# Altium schematic sheet 辅助电源.SchDoc(辅助电源)
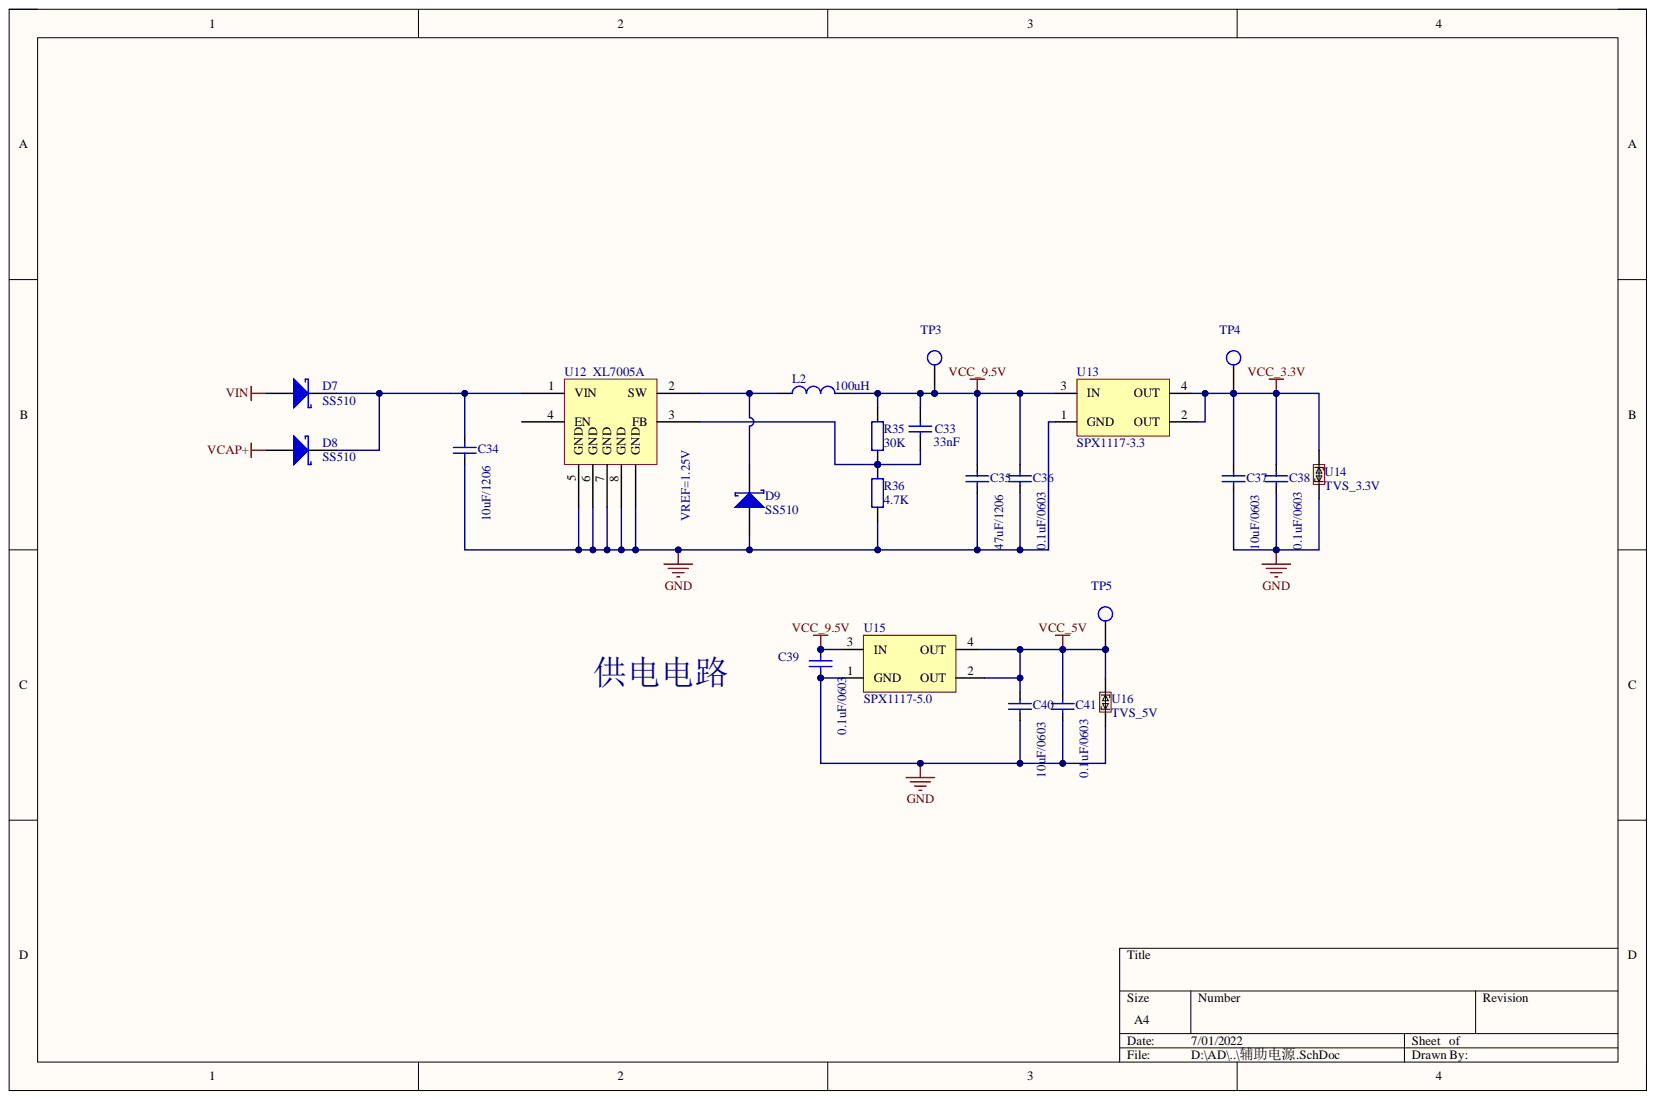
[diagram p0: 辅助电源.SchDoc]

## Parts
C33  33nF  [CAP_0603]
C34  10uF  value 10uF/1206  [CAP_1206]
C35  47uF  value 47uF/1206  [CAP_1206]
C36  0.1uF  value 0.1uF/0603  [CAP_0603]
C37  10uF  value 10uF/0603  [CAP_0603]
C38  0.1uF  value 0.1uF/0603  [CAP_0603]
C39  0.1uF  value 0.1uF/0603  [CAP_0603]
C40  10uF  value 10uF/0603  [CAP_0603]
C41  0.1uF  value 0.1uF/0603  [CAP_0603]
D7  SS510  [SMA]
D8  SS510  [SMA]
D9  SS510  [SMA]
L2  100uH  [CD-54]
R35  30K  [RES_0603]
R36  4.7K  [RES_0603]
TP3  ??  [TP]  (value not drawn)
TP4  ??  [TP]  (value not drawn)
TP5  ??  [TP]  (value not drawn)
U12  XL7005A  [SOP-8-EX]
U13  SPX1117-3.3  [SOT223]
U14  TVS_3.3V  [SOD323]
U15  SPX1117-5.0  [SOT223]
U16  TVS_5V  [SOD323]

## Nets
GND: C34.1 C35.1 C36.1 C37.1 C38.1 C39.1 C40.1 C41.1 D9.1 R36.1 U12.5(GND) U12.6(GND) U12.7(GND) U12.8(GND) U12.9(GND) U13.1(GND) U14.1 U15.1(GND) U16.1
VCAP+: D8.1
VCC_3.3V: C37.2 C38.2 TP4.1 U13.2(OUT) U13.4(OUT) U14.2
VCC_5V: C40.2 C41.2 TP5.1 U15.2(OUT) U15.4(OUT) U16.2
VCC_9.5V: C33.1 C35.2 C36.2 C39.2 L2.2 R35.2 TP3.1 U13.3(IN) U15.3(IN)
VIN: D7.1
NetC33_2: C33.2 R35.1 R36.2 U12.3(FB)
NetC34_2: C34.2 D7.2 D8.2 U12.1(VIN)
NetD9_2: D9.2 L2.1 U12.2(SW)

Not connected: U12 4(EN)

## Library data of the parts
C33 = Cap: 无极性电容; vol: 50v; Component Kind: Standard; LatestRevisionDate: 17-Jul-2002; LatestRevisionNote: Re-released for DXP Platform.; PackageReference: RAD-0.3; Published: 8-Jun-2000; Value: 33nF; Library Name: wyplib_passive.IntLib; Publisher: Altium Limited
C34 = Cap_1206: Capacitor; Component Kind: Standard; Library Name: LIN_Library .SchLib
C35 = Cap_1206: Capacitor; Value: 47uF/1206; Component Kind: Standard; Library Name: LIN_Library .SchLib
C36-C38 C40 C41 = Cap_0603: Capacitor; Component Kind: Standard; Library Name: LIN_Library .SchLib
C39 = Cap_0603: Capacitor; Value: 0.1uF/0603; Component Kind: Standard; Library Name: LIN_Library .SchLib
D7-D9 = D-Schottky: 肖特基二极管; Code_JEDEC: DO-214-AA; Component Kind: Standard; LatestRevisionDate: 17-Jul-2002; LatestRevisionNote: Re-released for DXP Platform.; PackageReference: SMB; Published: 8-Jun-2000; Type: SS510; Library Name: wyplib_active.IntLib; Publisher: Altium Limited
L2 = Inductor: 电感; Code_IEC: 1005; Code_JEITA: 0402; Component Kind: Standard; LatestRevisionDate: 17-Jul-2002; LatestRevisionNote: Re-released for DXP Platform.; PackageReference: 0402-A; Published: 8-Jun-2000; Value: 100uH; Library Name: wyplib_passive.IntLib; Publisher: Altium Limited
R35 R36 = Res1: 电阻; Component Kind: Standard; LatestRevisionDate: 17-Jul-2002; LatestRevisionNote: Re-released for DXP Platform.; PackageReference: AXIAL-0.4; Published: 8-Jun-2000; Library Name: wyplib_passive.IntLib; Publisher: Altium Limited
  R35: Value: 30K
  R36: Value: 4.7K
TP3-TP5 = TP: Component Kind: Standard; Library Name: LIN_Library .SchLib
U12 = XL7005A: 5-80v-buck; Component Kind: Standard; Library Name: wyplib_active.IntLib
U13 U15 = SPX1117: Component Kind: Standard; Library Name: STM32_POWER.SchLib
U14 U16 = TVS: Component Kind: Standard; Library Name: Chen_Library.IntLib
